# Altium schematic sheet Main.SchDoc
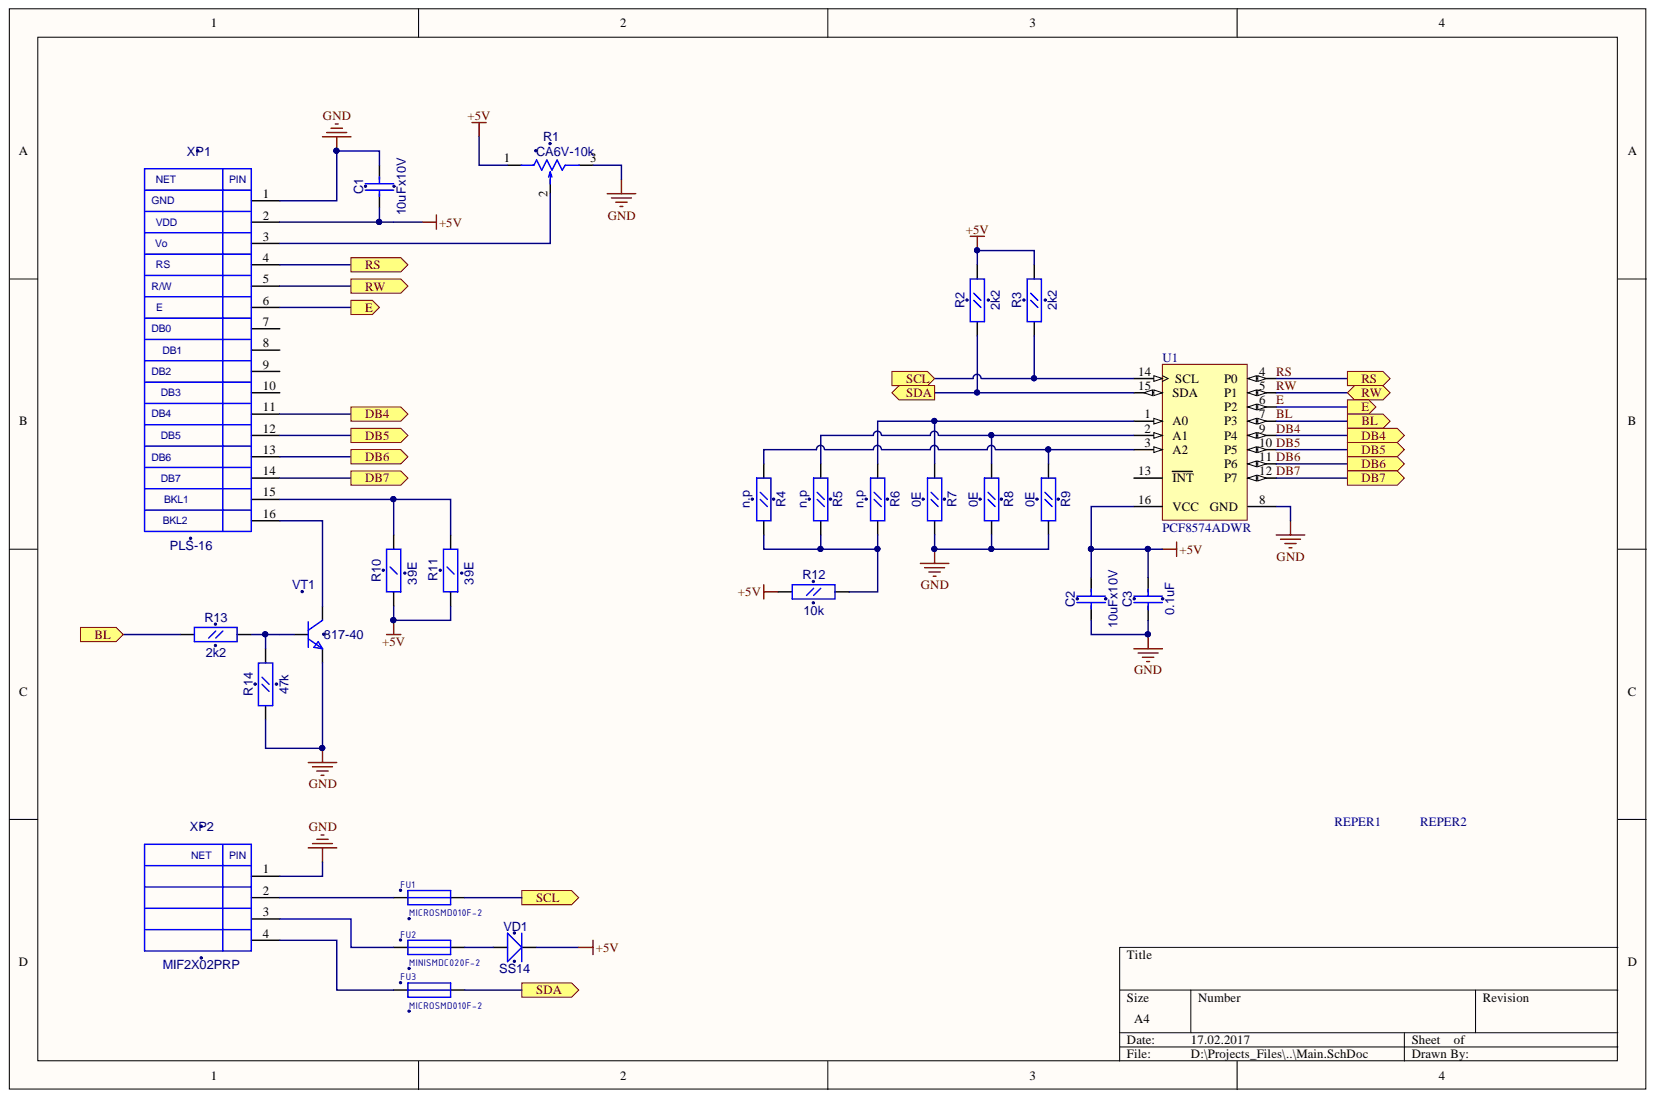
[diagram p0: Main.SchDoc]

## Parts
C1  10uFx10V  [CC0805]
C2  10uFx10V  [CC0805]
C3  0.1uF  [CC0603]
FU1  MICROSMD010F-2  [RC1210]
FU2  MINISMDC020F-2  [RC1812]
FU3  MICROSMD010F-2  [RC1210]
R1  CA6V-10k  [3318P-1]
R2  2k2  [RC0603]
R3  2k2  [RC0603]
R4  n.p  [RC0603]
R5  n.p  [RC0603]
R6  n.p  [RC0603]
R7  0E  [RC0603]
R8  0E  [RC0603]
R9  0E  [RC0603]
R10  39E  [RC1206]
R11  39E  [RC1206]
R12  10k  [RC0603]
R13  2k2  [RC0603]
R14  47k  [RC0603]
REPER1  REPER1.2  [REPER1.2]  (value not drawn)
REPER2  REPER1.2  [REPER1.2]  (value not drawn)
U1  PCF8574ADWR  [DW16_N]
VD1  SS14  [SMA]
VT1  817-40  [SOT-23(123)]
XP1  PLS-16  [PIN16S]
XP2  MIF2X02PRP  [MIF2X02PRP]

## Ports
BL, DB4, DB5, DB6, DB7, E, RS, RW, SCL, SDA

## Nets
+5V: C1.1 C2.2 C3.2 R1.1 R2.2 R3.2 R10.1 R11.1 R12.1 U1.16(VCC) VD1.2 XP1.2
GND: C1.2 C2.1 C3.1 R1.3 R7.2 R8.2 R9.2 R14.1 U1.8(GND) VT1.2 XP1.1 XP2.1
BL: R13.1 U1.7(P3) ; port BL
DB4: U1.9(P4) XP1.11 ; port DB4
DB5: U1.10(P5) XP1.12 ; port DB5
DB6: U1.11(P6) XP1.13 ; port DB6
DB7: U1.12(P7) XP1.14 ; port DB7
E: U1.6(P2) XP1.6 ; port E
RS: U1.4(P0) XP1.4 ; port RS
RW: U1.5(P1) XP1.5 ; port RW
SCL: FU1.2 R3.1 U1.14(SCL) ; port SCL
SDA: FU3.2 R2.1 U1.15(SDA) ; port SDA
NetFU1_1: FU1.1 XP2.2
NetFU2_1: FU2.1 XP2.3
NetFU2_2: FU2.2 VD1.1
NetFU3_1: FU3.1 XP2.4
NetR1_2: R1.2 XP1.3
NetR4_1: R4.1 R9.1 U1.3(A2)
NetR4_2: R4.2 R5.2 R6.2 R12.2
NetR5_1: R5.1 R8.1 U1.2(A1)
NetR6_1: R6.1 R7.1 U1.1(A0)
NetR10_2: R10.2 R11.2 XP1.15
NetR13_2: R13.2 R14.2 VT1.1
NetVT1_3: VT1.3 XP1.16

Not connected: U1 13(INT); XP1 7 8 9 10

## Library data of the parts
C1 C2 = CC0805: Component Kind: Standard; Description: CC0805; Value: 10uFx10V; Library Name: gprs_modem_2.SchLib
C3 = CC0603: Component Kind: Standard; Description: CC0603; Value: 0.1uF; Library Name: gprs_modem_2.SchLib
FU1 FU3 = MICROSMD: Component Kind: Standard; Description: MICROSMD; Value: MICROSMD010F-2; Library Name: gprs_modem_2.SchLib
FU2 = MINISMDC: Component Kind: Standard; Description: MINISMDC; Value: MINISMDC020F-2; Library Name: gprs_modem_2.SchLib
R1 = 3318P-1: EVND8AA03B25; Component Kind: Standard; Value: CA6V-10k; Library Name: Schetchik.SchLib
R2-R9 R12-R14 = RC0603: Component Kind: Standard; Description: RC0603; Library Name: gprs_modem_2.SchLib
  R2 R3 R13: Value: 2k2
  R4-R6: Value: n.p
  R7-R9: Value: 0E
  R12: Value: 10k
  R14: Value: 47k
R10 R11 = RC1206: Component Kind: Standard; Description: RC1206; Value: 39E; Library Name: gprs_modem_2.SchLib
U1 = PCF8574ADWR: Remote 8-Bit I/O Expander for I2C-Bus, 2.5 to 6.0 V, -40 to 85 degC, 16-pin SOIC (DW), Green (RoHS & no Sb/Br); Manufacturer: Texas Instruments; # of I/Os: 8; fSCLK(Max)(MHz): 0.1; I2C Address: 0111 xxx; Interrupt Output: Yes; Max Frequency(kHz): 100; SubFamily: I2C IO Expander; VCC(Max)(V): 6; VCC(Min)(V): 2.5; Voltage Nodes(V): 5, 3.3; Code_JEDEC: MS-013-AA; Component Kind: Standard; ComponentLink1Description: Datasheet; ComponentLink1URL: http://www.ti.com/general/docs/lit/getliterature.tsp?genericPartNumber=PCF8574A&fileType=pdf; ComponentLink2Description: Package Specification; ComponentLink2URL: http://www.ti.com/litv/pdf/msoi003g; DatasheetVersion: SCPS069D; Eco-Plan: Green (RoHS & no Sb/Br); Library Name: Texas Instruments Interface I2C.IntLib; Mounting Technology: Surface Mount; PackageDescription: 16-Pin Small Outline Integrated Circuit, 10.3 x 7.5 mm, Pitch 1.27 mm Pitch; PackageReference: DW16; PackageVersion: revG, Jan-2011; PartNumber: PCF8574ADWR; Push-Pull I/O Type: Yes; Reset Input: No; Supplier 1: Digi-Key; Supplier 2: Digi-Key; Supplier 3: Digi-Key; Supplier 4: Newark; Supplier 5: Mouser; Supplier Part Number 1: 296-13105-2-ND; Supplier Part Number 2: 296-13105-1-ND; Supplier Part Number 3: 296-13105-6-ND; Supplier Part Number 4: 75C5233; Supplier Part Number 5: 595-PCF8574ADWR; Vcc range(V): 2.5 to 6.0
VD1 = SS14: Schottky Rectifier; ComponentField6: Published 8-Jun-2000, Modified 10-July-2000: SIM Model Added.; OriginalName: 10BQ100; Component Kind: Standard; Simulation: 10BQ100; Value: SS14; Library Name: gprs_modem_2.SchLib
VT1 = BC846BLT1: ComponentField7: Published 29-Sep-2000; Component Kind: Standard; Description: BC846BLT1; OriginalName: BC846BLT1; Simulation: BC846BLT1; Value: 817-40; Library Name: gprs_modem_2.SchLib
REPER1 REPER2 = REPER1.2: Component Kind: Standard; Description: REPER1.2; Library Name: gprs_modem_2.SchLib
XP1 = PLS-16: Component Kind: Standard; Description: PLS-16; Library Name: Schetchik.SchLib
XP2 = MIF2X02PRP: Component Kind: Standard; Description: MIF2X02PRP; Library Name: gprs_modem_2.SchLib
